# Altium schematic sheet NUCLEO-F767ZI_2.0_2.2 LCD.schdoc
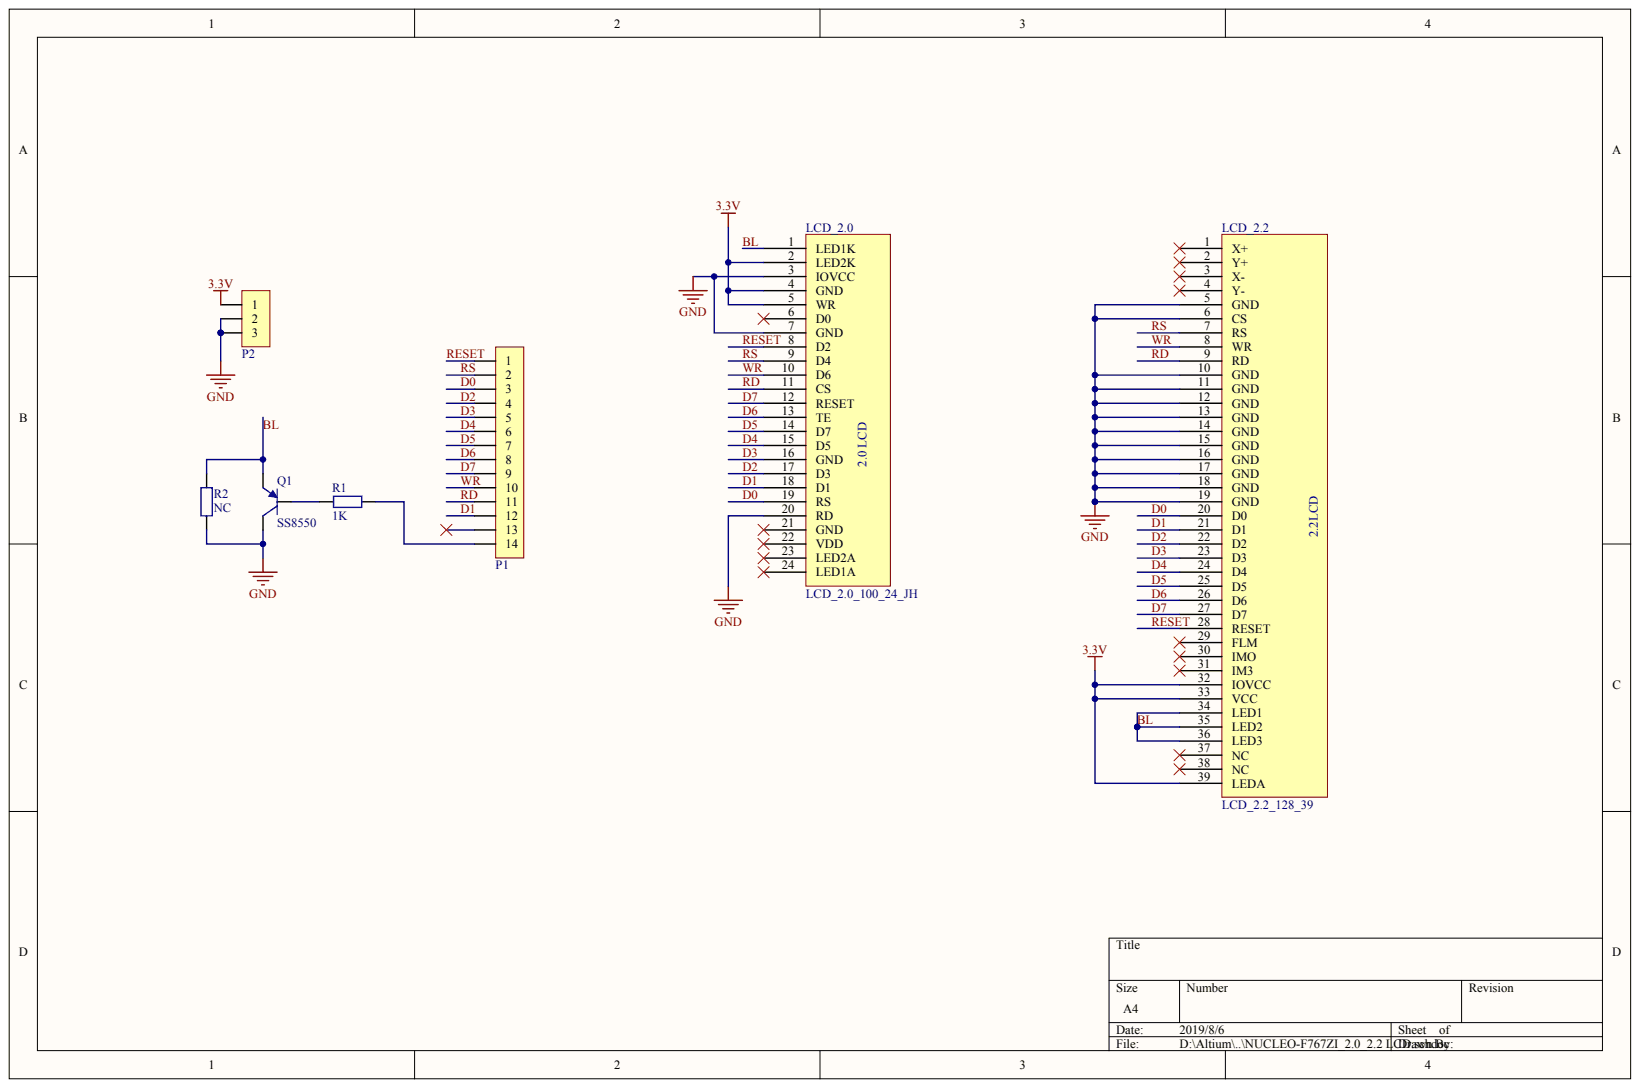
[diagram p0: NUCLEO-F767ZI_2.0_2.2 LCD.schdoc]

## Parts
LCD_2.0  LCD_2.0_100_24_JH
LCD_2.2  LCD_2.2_128_39
P1  HDR-1X14  (value not drawn)
P2  HDR-1X3  (value not drawn)
Q1  SS8550
R1  1K
R2  NC

## Nets
3.3V: LCD_2.0.2(LED2K) LCD_2.0.4(GND) LCD_2.0.5(WR) LCD_2.2.32(IOVCC) LCD_2.2.33(VCC) LCD_2.2.39(LEDA) P2.1
GND: LCD_2.0.3(IOVCC) LCD_2.0.7(GND) LCD_2.0.20(RD) LCD_2.2.5(GND) LCD_2.2.6(CS) LCD_2.2.10(GND) LCD_2.2.11(GND) LCD_2.2.12(GND) LCD_2.2.13(GND) LCD_2.2.14(GND) LCD_2.2.15(GND) LCD_2.2.16(GND) LCD_2.2.17(GND) LCD_2.2.18(GND) LCD_2.2.19(GND) P2.2 P2.3 Q1.3 R2.1
BL: LCD_2.0.1(LED1K) LCD_2.2.34(LED1) LCD_2.2.35(LED2) LCD_2.2.36(LED3) Q1.2 R2.2
D0: LCD_2.0.19(RS) LCD_2.2.20(D0) P1.3
D1: LCD_2.0.18(D1) LCD_2.2.21(D1) P1.12
D2: LCD_2.0.17(D3) LCD_2.2.22(D2) P1.4
D3: LCD_2.0.16(GND) LCD_2.2.23(D3) P1.5
D4: LCD_2.0.15(D5) LCD_2.2.24(D4) P1.6
D5: LCD_2.0.14(D7) LCD_2.2.25(D5) P1.7
D6: LCD_2.0.13(TE) LCD_2.2.26(D6) P1.8
D7: LCD_2.0.12(RESET) LCD_2.2.27(D7) P1.9
RD: LCD_2.0.11(CS) LCD_2.2.9(RD) P1.11
RESET: LCD_2.0.8(D2) LCD_2.2.28(RESET) P1.1
RS: LCD_2.0.9(D4) LCD_2.2.7(RS) P1.2
WR: LCD_2.0.10(D6) LCD_2.2.8(WR) P1.10
NetP1_14: P1.14 R1.2
NetQ1_1: Q1.1 R1.1

Not connected: LCD_2.0 6(D0) 21(GND) 22(VDD) 23(LED2A) 24(LED1A); LCD_2.2 1(X+) 2(Y+) 3(X-) 4(Y-) 29(FLM) 30(IMO) 31(IM3) 37(NC) 38(NC); P1 13

## Library data of the parts
P1 = (no symbol name): BOM名称: 14P接插件; 版权归属: 源创客; 学习资源: www.ooocke.com
P2 = (no symbol name): BOM名称: 3P接插件; 版权归属: 源创客; 学习资源: www.ooocke.com
Q1 = (no symbol name): BOM名称: 高频放大-PNP型; 版权归属: 源创客; 属性: 40V 1.5A 1W 100MHZ; 学习资源: www.ooocke.com
R1 R2 = (no symbol name): BOM名称: 贴片电阻; 版权归属: 源创客; 学习资源: www.ooocke.com
